# Altium schematic sheet TopLevel.SchDoc
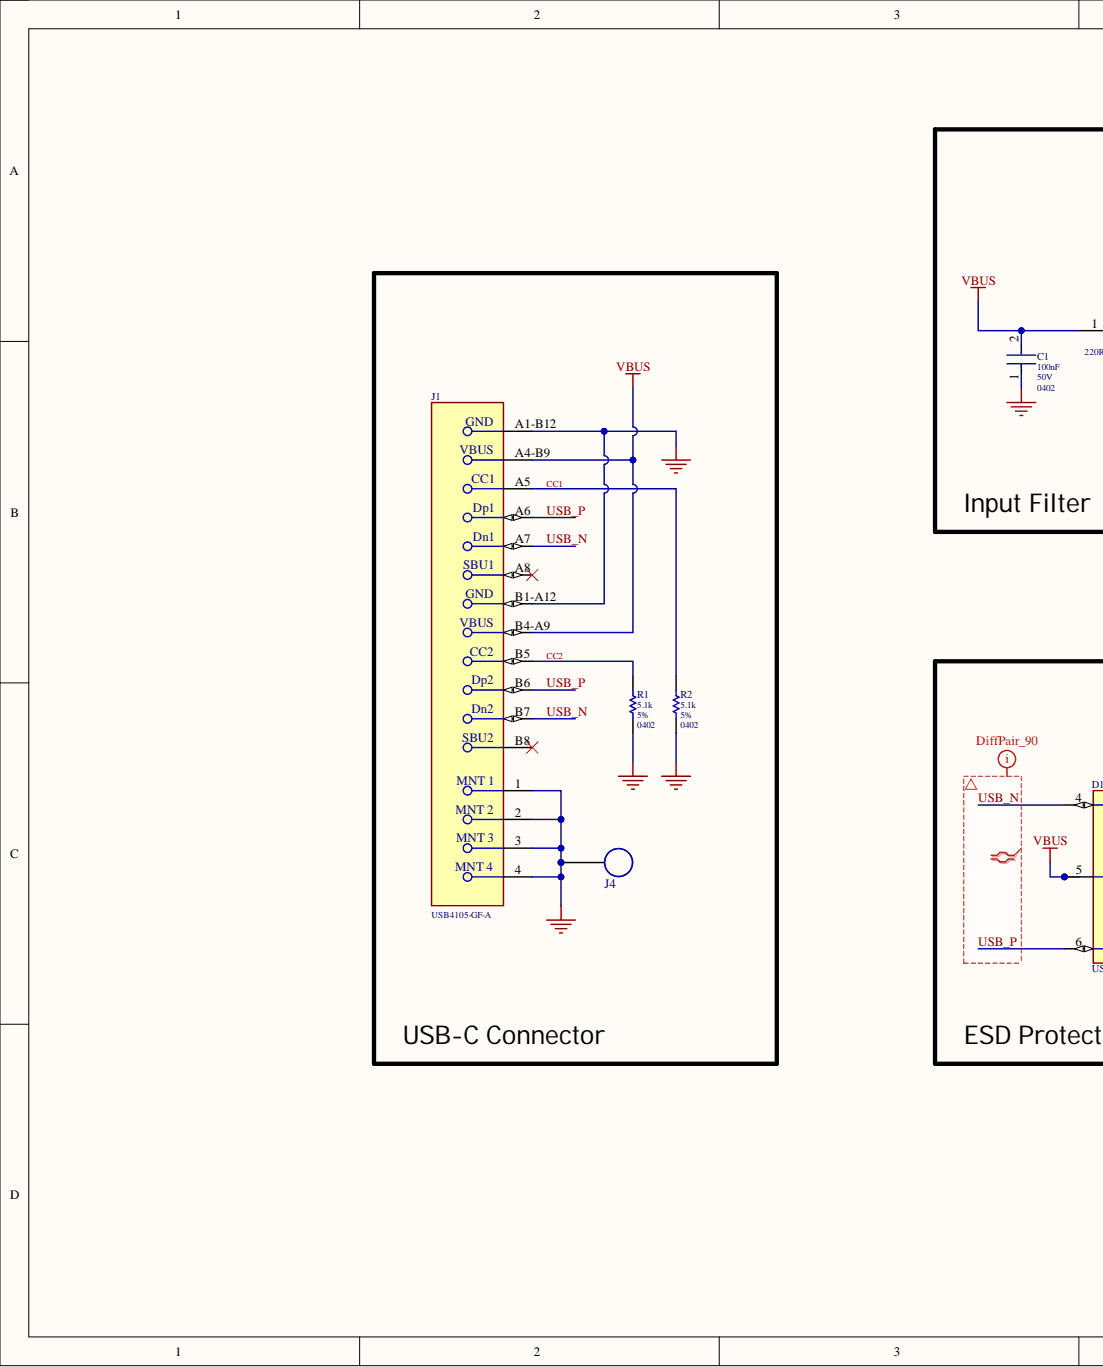
[diagram p0: TopLevel.SchDoc, left]
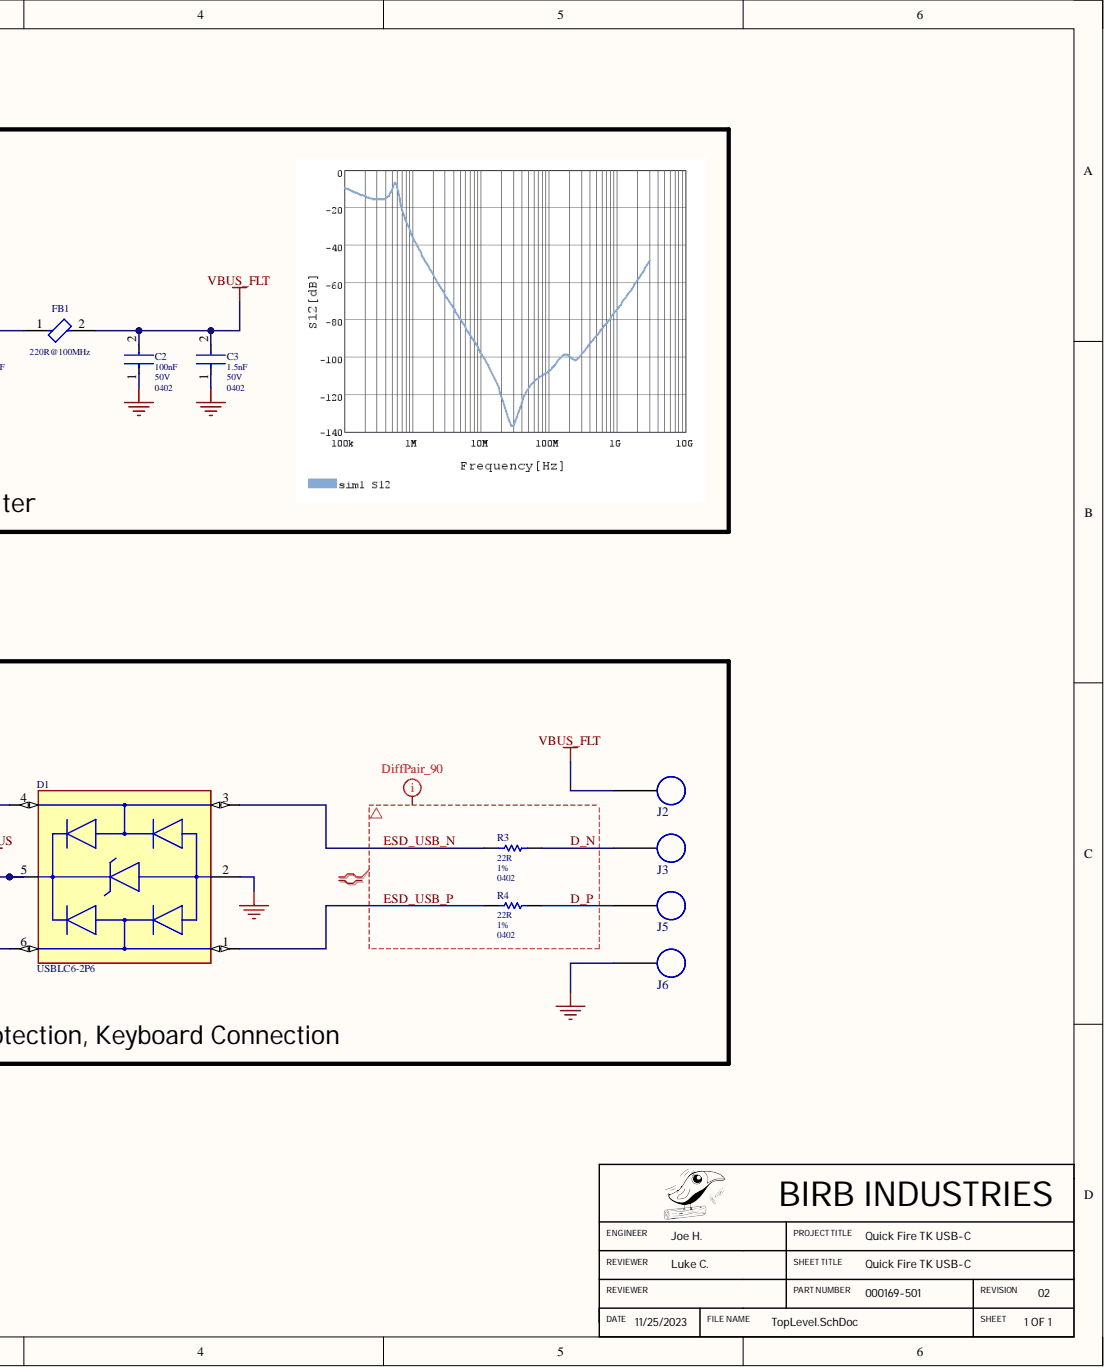
[diagram p1: TopLevel.SchDoc, right]

## Parts
C1  value 100nF  Voltage: 50V  [0402]  (hidden comment: GRT155R71H104KE01D)
C2  value 100nF  Voltage: 50V  [0402]  (hidden comment: GRT155R71H104KE01D)
C3  value 1.5nF  Voltage: 50V  [0402]  (hidden comment: GRT155R71H152KE01D)
D1  USBLC6-2P6  [FP-SOT-666-MFG]
FB1  ESR (Equivalent Series Resistance): 220R@100MHz  [FP-BLM18-0_15-t0_8-IPC_B]  (hidden comment: BLM18PG221SN1D)
J1  USB4105-GF-A  [FP-USB4105-GF-A-MFG]
J2  20AWG_WTB_TH  [20AWG_WTB_TH]  (value not drawn)  (no BOM)
J3  26AWG_WTB_TH  [26AWG_WTB_TH]  (value not drawn)  (no BOM)
J4  20AWG_WTB_TH  [20AWG_WTB_TH]  (value not drawn)  (no BOM)
J5  26AWG_WTB_TH  [26AWG_WTB_TH]  (value not drawn)  (no BOM)
J6  20AWG_WTB_TH  [20AWG_WTB_TH]  (value not drawn)  (no BOM)
R1  value 5.1k  Tolerance: 5%  [0402]  (hidden comment: RC0402JR-075K1L)
R2  value 5.1k  Tolerance: 5%  [0402]  (hidden comment: RC0402JR-075K1L)
R3  value 22R  Tolerance: 1%  [0402]  (hidden comment: RC0402FR-0722RL)
R4  value 22R  Tolerance: 1%  [0402]  (hidden comment: RC0402FR-0722RL)

## Nets
GND: C1.1 C2.1 C3.1 D1.2 J1.1 J1.2 J1.3 J1.4 J1.A1-B12 J1.B1-A12 J4.1 J6.1 R1.1 R2.1
VBUS: C1.2 D1.5 FB1.1 J1.A4-B9 J1.B4-A9
VBUS_FLT: C2.2 C3.2 FB1.2 J2.1
CC1: J1.A5 R2.2
CC2: J1.B5 R1.2
D_N: J3.1 R3.2
D_P: J5.1 R4.2
ESD_USB_N: D1.3 R3.1
ESD_USB_P: D1.1 R4.1
USB_N: D1.4 J1.A7 J1.B7
USB_P: D1.6 J1.A6 J1.B6

Not connected: J1 A8 B8

## Library data of the parts
C1 C2 = CMP-06035-014200-1: Multi-Layer Ceramic Capacitor 100nF 50V X7R ±10% 0402 Paper T/R; Manufacturer: Murata; Component Kind: Standard; Library Name: Altium Content Vault; Part Number: GRT155R71H104KE01D; Tolerance: 10%
C3 = CMP-06035-024210-1: Multi-Layer Ceramic Capacitor 1.5nF 50V X7R ±10% 0402 Paper T/R; Manufacturer: Murata; Component Kind: Standard; Library Name: Altium Content Vault; Part Number: GRT155R71H152KE01D; Tolerance: 10%
D1 = CMP-12225-000058-1: TVS DIODE 5.25V 17V SOT666; Breakdown Voltage: 6V; Capacitance: 3.5pF; Direction: Unidirectional; Element Configuration: Dual; ESD Protection: Yes; Leakage Current: 150nA; Number of Bidirectional Channels: 2; Number of Elements: 1; Operating Supply Voltage: 5V; Peak Pulse Current: 5A; Test Current: 1mA; Case/Package: SOT-666; Clamping Voltage: 17V; Component Kind: Standard; Contact Plating: Tin; Depth: 1.3mm; Height: 0.6mm; Lead Free: Lead Free; Length: 1.7mm; Library Name: Altium Content Vault; Max Breakdown Voltage: 6V; Max Operating Temperature: 125°C; Max Reverse Leakage Current: 150nA; Min Breakdown Voltage: 6V; Min Operating Temperature: -40°C; Mount: Surface Mount; Number of Channels: 2; Number of Pins: 6; Package Quantity: 1; Packaging: Tape and Reel; Polarity: Unidirectional; Power Line Protection: Yes; Radiation Hardening: No; REACH SVHC: No SVHC; Reverse Breakdown Voltage: 6V; Reverse Standoff Voltage: 5V; Termination: SMD/SMT; Width: 1.3mm; Working Voltage: 5V
FB1 = CMP-0686-00569-3: Chip Ferrite Bead, 0603, 220Ω @ 100MHz, 0.1Ω, 25%, 1.4A; Manufacturer Series: BLM18P; DC Resistance (DCR): 100mR; Impedance: 220R; Material: Steel; Number of Circuits: 1; Shielding: Unshielded; Case Code (Imperial): 0603; Case Code (Metric): 1608; Case/Package: 0603; Component Kind: Standard; Core Material: Ferrite; Current Rating: 1.4A; DC Current: 1.4A; DC Resistance-Max: 100mR; Depth: 0.8mm; Height: 0.8mm; Lead Free: Lead Free; Length: 1.6mm; Library Name: Altium Content Vault; Max Current Rating: 1.4A; Max DC Current: 1.4A; Max Operating Temperature: 125°C; Min Operating Temperature: -55°C; Number of Functions: 1; Number of Lines: 1; Number of Pins: 2; Package Quantity: 1; Packaging: Tape and Reel; Radiation Hardening: No; REACH SVHC: No SVHC; Resistance: 100mR; RoHS Compliant: Yes; Series: BLM18P; Termination: SMD/SMT; Test Frequency: 100MHz; Tolerance: 25%; Weight: 7.1E-05oz; Width: 0.8mm
J1 = CMP-245163-000001-1: CONN RCPT USB2.0 TYPEC 5A; Manufacturer: GCT; Conn Orientation: Right Angle; Current Rating: 5/6.25/1.25/0.25A; Is Female: yes; Number Of Contacts: 16; Voltage Rating: 20V; Automotive: No; Category: Conn; Component Kind: Standard; ComponentLink2Description: Datasheet; ComponentLink2URL: https://gct.co/files/drawings/usb4105.pdf; Contact Material: Gold,Nickel; Device Class L1: Connectors; Device Class L2: USB Connectors; Device Class L3: unset; Digikey Description: CONN RCPT USB2.0 TYPEC 5A; Height: 3.46mm; Lead Free: Yes; Library Name: Altium Content Vault; Manufacturer Part Number: USB4105-GF-A; Octopart: https://octopart.com/usb4105-gf-a-gct-106627878; Package: 0; RoHS: TRUE; Temperature: -40°C to +85°C; Temperature Range High: +85°C; Temperature Range Low: -40°C; Usb Standard: USB 2.0
J2 J4 J6 = 20AWG_WTB_TH: 20AWG Wire to Board Through Hole; Library Name: 000169(Quick_Fire_TK_USBC).SchLib
J3 J5 = 26AWG_WTB_TH: 26AWG Wire to Board Through Hole; Library Name: 000169(Quick_Fire_TK_USBC).SchLib
R1 R2 = CMP-2002-08025-1: Thick Film Resistors - SMD 5.1 kOhms 62.5mW 0402 5%; Manufacturer: Yageo / Phycomp; Component Kind: Standard; Library Name: Altium Content Vault; Part Number: RC0402JR-075K1L
R3 R4 = CMP-2002-07520-1: Thick Film Resistors 22 Ohms 62.5mW 0402 1%; Manufacturer: Yageo / Phycomp; Component Kind: Standard; Library Name: Altium Content Vault; Part Number: RC0402FR-0722RL
